# Altium schematic sheet KC4D_EXT_MAG_V1.2.SchDoc(KC4D_EXT_MAG_V1.2)
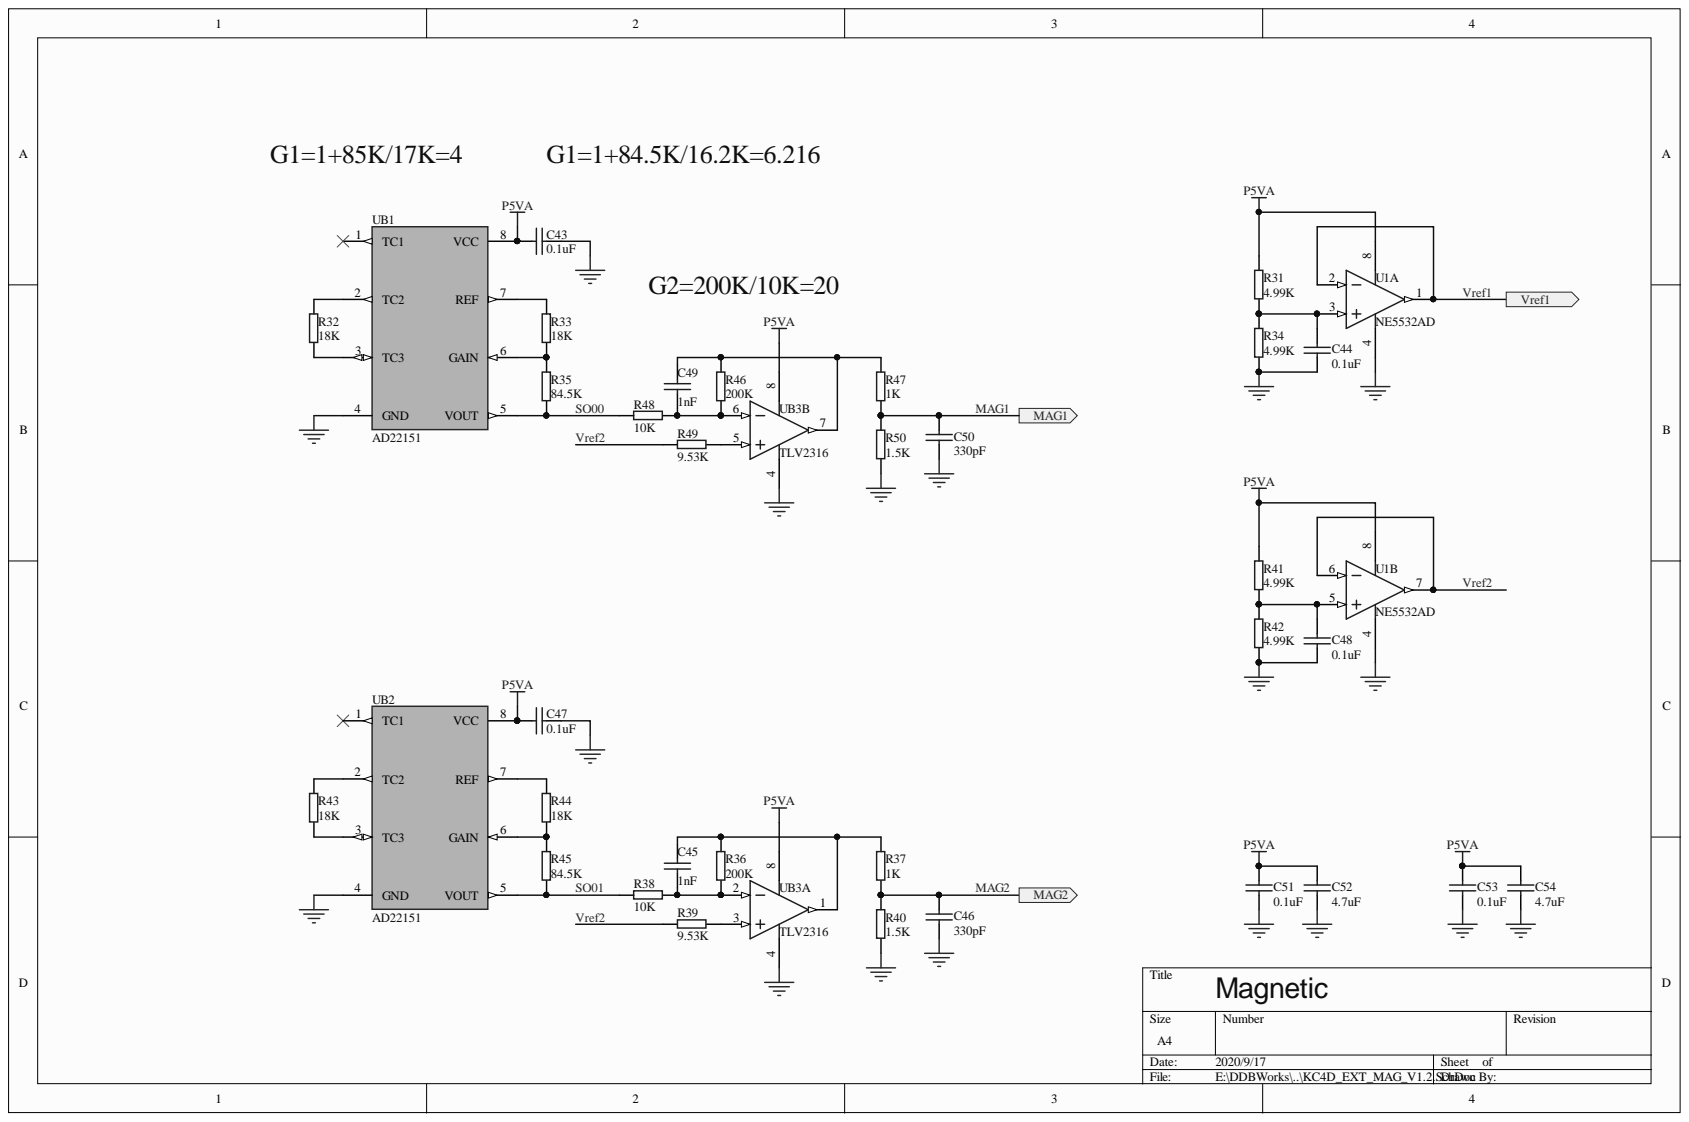
[diagram p0: KC4D_EXT_MAG_V1.2.SchDoc]

## Parts
C43  0.1uF  [C0603J]
C44  0.1uF  [C0603J]
C45  1nF  [C0603J]
C46  330pF  [C0603J]
C47  0.1uF  [C0603J]
C48  0.1uF  [C0603J]
C49  1nF  [C0603J]
C50  330pF  [C0603J]
C51  0.1uF  [C0603J]
C52  4.7uF  [C0603J]
C53  0.1uF  [C0603J]
C54  4.7uF  [C0603J]
R31  4.99K  [R0603J]
R32  18K  [R0603J]
R33  18K  [R0603J]
R34  4.99K  [R0603J]
R35  84.5K  [R0603J]
R36  200K  [R0603J]
R37  1K  [R0603J]
R38  10K  [R0603J]
R39  9.53K  [R0603J]
R40  1.5K  [R0603J]
R41  4.99K  [R0603J]
R42  4.99K  [R0603J]
R43  18K  [R0603J]
R44  18K  [R0603J]
R45  84.5K  [R0603J]
R46  200K  [R0603J]
R47  1K  [R0603J]
R48  10K  [R0603J]
R49  9.53K  [R0603J]
R50  1.5K  [R0603J]
U1  NE5532AD  [TI-D8_N]  (parts A,B)
UB1  AD22151  [M08A_N]
UB2  AD22151  [M08A_N]
UB3  TLV2316  [M08A_N]  (parts A,B)

## Ports
MAG1, MAG2, Vref1

## Nets
GND: C43.2 C44.1 C46.2 C47.2 C48.1 C50.2 C51.2 C52.2 C53.2 C54.2 R34.1 R40.1 R42.1 R50.1 U1.4 UB1.4(GND) UB2.4(GND) UB3.4
P5VA: C43.1 C47.1 C51.1 C52.1 C53.1 C54.1 R31.2 R41.2 U1.8 UB1.8(VCC) UB2.8(VCC) UB3.8
MAG1: C50.1 R47.1 R50.2 ; port MAG1
MAG2: C46.1 R37.1 R40.2 ; port MAG2
SO00: R35.1 R48.2 UB1.5(VOUT)
SO01: R38.2 R45.1 UB2.5(VOUT)
Vref1: U1.1 U1.2 ; port Vref1
Vref2: R39.2 R49.2 U1.6 U1.7
NetC44_2: C44.2 R31.1 R34.2 U1.3
NetC45_1: C45.1 R36.1 R37.2 UB3.1
NetC45_2: C45.2 R36.2 R38.1 UB3.2
NetC48_2: C48.2 R41.1 R42.2 U1.5
NetC49_1: C49.1 R46.1 R47.2 UB3.7
NetC49_2: C49.2 R46.2 R48.1 UB3.6
NetR32_1: R32.1 UB1.3(TC3)
NetR32_2: R32.2 UB1.2(TC2)
NetR33_1: R33.1 R35.2 UB1.6(GAIN)
NetR33_2: R33.2 UB1.7(REF)
NetR39_1: R39.1 UB3.3
NetR43_1: R43.1 UB2.3(TC3)
NetR43_2: R43.2 UB2.2(TC2)
NetR44_1: R44.1 R45.2 UB2.6(GAIN)
NetR44_2: R44.2 UB2.7(REF)
NetR49_1: R49.1 UB3.5

Not connected: UB1 1(TC1); UB2 1(TC1)

## Library data of the parts
C43-C54 = CAP: PCB3D: 0805_0_0000_C; Part Field 4: 30pF; Part Field 3: Altium Limited; Part Field 2: July-2002: Re-released for DXP Platform.; Part Field 1: 8-Jun-2000; Component Kind: Standard
R31-R50 = Ra_DTE: Library Name: DTE1.SchLib; Component Kind: Standard
  R32 R33 R35-R40 R43-R50: PCB3D: 0805_0_0000_R
U1 = NE5532AD: Dual Low-Noise Operational Amplifier, 10 to 30 V, 0 to 70 degC, 8-pin SOIC (D8), Green (RoHS & no Sb/Br); Manufacturer: Texas Instruments; Vn at 1kHz(Typ)(nV/rtHz): 5; Vio (25C)(Max)(mV): 4; Total Supply Voltage(Min)(+5V is 5, +/-5V is 10): 10; Total Supply Voltage(Max)(+5V is 5, +/-5V is 10): 30; Sub Family: General Purpose Amplifier, High Supply Voltage Amplifier (>=30V), Low Noise Amp (<=10nV/rtHz); Slew Rate(Typ)(V/us): 9; Number of Channels: 2; IIB(Max)(pA): 800000; CMRR(Min)(dB): 70; Supplier Part Number 4: 595-NE5532AD; Supplier Part Number 3: 75C4431; Supplier Part Number 2: 1103060; Supplier Part Number 1: NE5532AD-ND; Supplier 4: Mouser; Supplier 3: Newark; Supplier 2: Farnell; Supplier 1: Digi-Key; PartNumber: NE5532AD; PackageVersion: 4040047-3/M, 06/2011; PackageReference: D8; PackageDescription: 8-Pin Plastic Small Outline, Body 4.9 x 3.9 mm, Pitch 1.27 mm; Mounting Technology: Surface Mount; Library Name: TI Amplifiers and Linear Operational Amplifier General Purpose.IntLib; Iq per channel(Max)(mA): 4; GBW(Typ)(MHz): 10; Eco-Plan: Green (RoHS & no Sb/Br); DatasheetVersion: SLOS075I; ComponentLink3URL: http://www.ti.com/litv/pdf/msoi002j; ComponentLink3Description: Package Specification; ComponentLink2URL: http://www.ti.com/lit/ds/symlink/ne5532a.pdf; ComponentLink2Description: Datasheet; ComponentLink1URL: http://www.ti.com/; ComponentLink1Description: Manufacturer URL; Component Kind: Standard; Code_JEDEC: MS-012
UB1 UB2 = AD22151YR: Component Kind: Standard; Library Name: DTE_LibS.SchLib
UB3 = LM6132AIMX: Dual Low Power 10 MHz Rail-to-Rail I/O Operational Amplifier, 8-pin Narrow SOIC; Manufacturer: National Semiconductor; PowerWise: N; PackageTopMarking: NSZXTT*LM61*32AIM; CompType: Amplifiers; Simulation: LM6132A; RoHS: N; Published: 1-Mar-2010; PinCount: 8; PartNumber: LM6132AIMX; PackageVersion: revM, May-2009; PackageReference: M08A; PackageDescription: SOIC Narrow, 4.9 x 3.9 x 1.45mm, 8 Lead, 1.27mm Pitch; Library Name: NSC Amplifier.IntLib; DatasheetVersion: Nov-2004; ComponentLink3URL: http://www.national.com/packaging/folders/m08a.html; ComponentLink3Description: M08A Package Page; ComponentLink2URL: http://www.national.com/pf/LM/LM6132.html; ComponentLink2Description: LM6132 Web Page; ComponentLink1URL: http://www.national.com/ds/LM/LM6132.pdf; ComponentLink1Description: LM6132 Datasheet; Component Kind: Standard; Code_JEDEC: MS-012-AA; Code_IPC: SOIC127P600-8; Publisher: Altium Limited
